# Altium schematic sheet IO+ROM board.SchDoc("IO+ROM board")
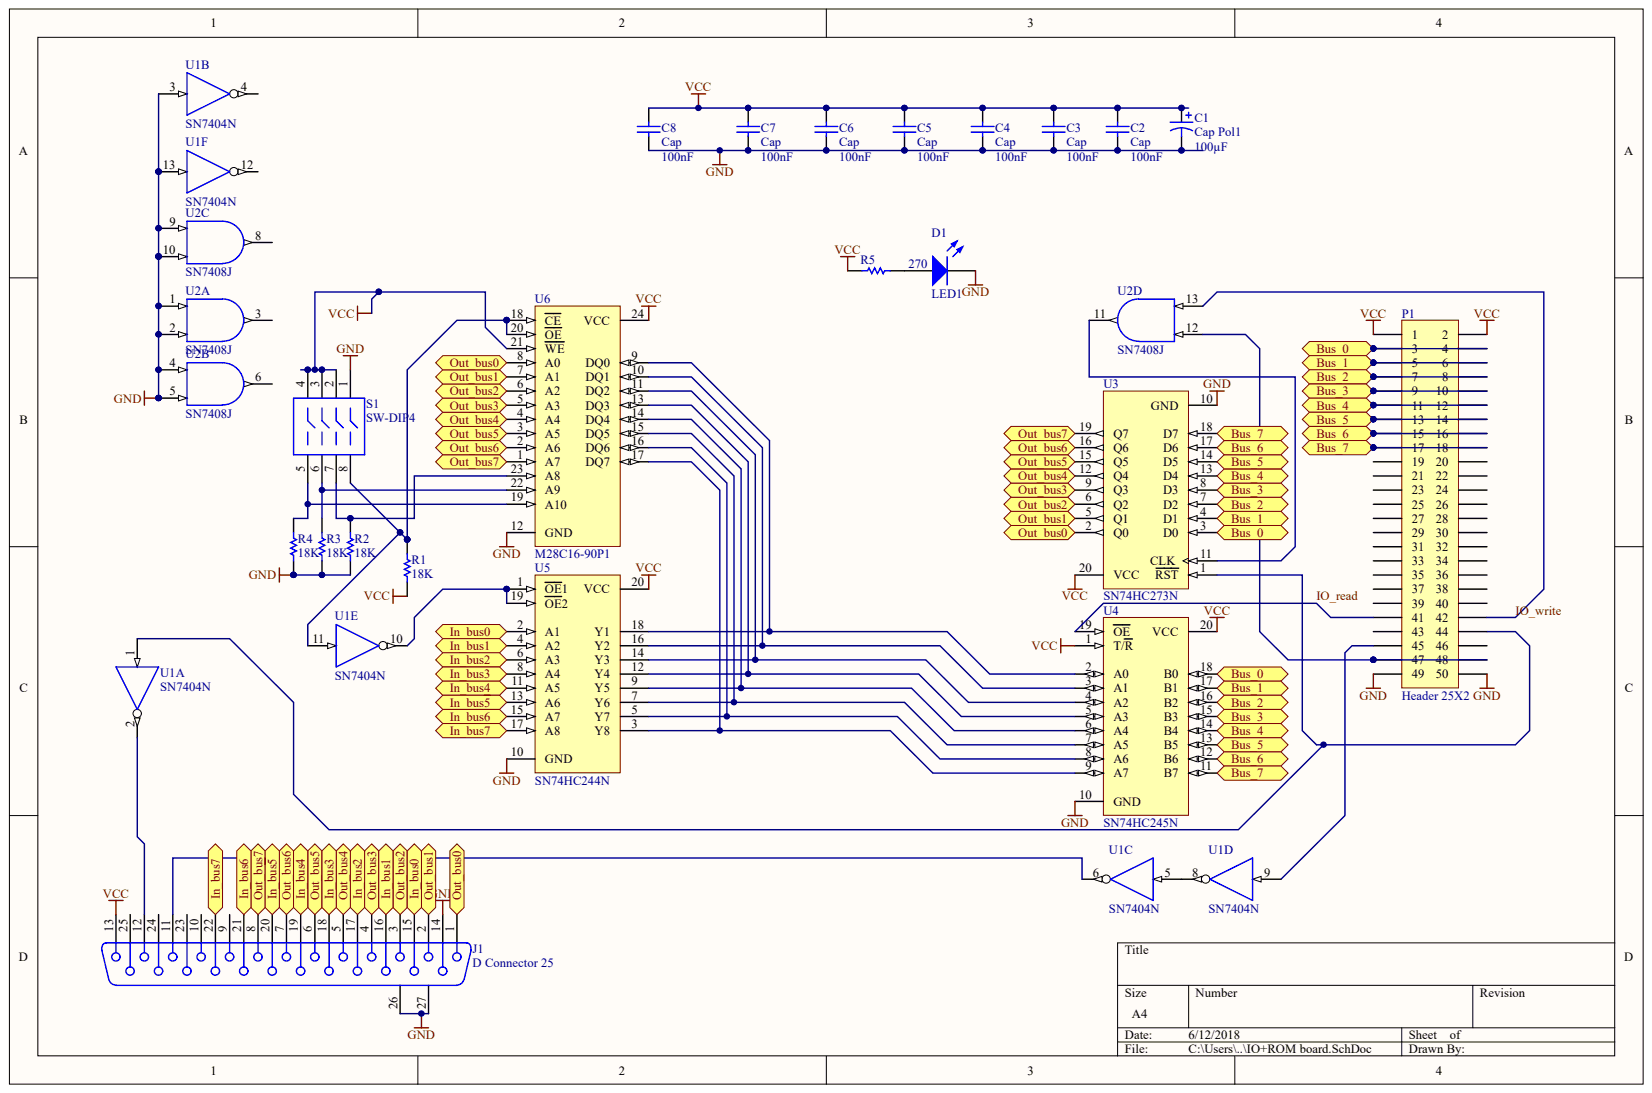
[diagram p0: IO+ROM board.SchDoc]

## Parts
C1  Cap Pol1  value 100µF
C2  Cap  value 100nF
C3  Cap  value 100nF
C4  Cap  value 100nF
C5  Cap  value 100nF
C6  Cap  value 100nF
C7  Cap  value 100nF
C8  Cap  value 100nF
D1  LED1
J1  D Connector 25
P1  Header 25X2
R1  value 18K  (hidden comment: Res1)
R2  value 18K  (hidden comment: Res1)
R3  value 18K  (hidden comment: Res1)
R4  value 18K  (hidden comment: Res1)
R5  value 270  (hidden comment: Res1)
S1  SW-DIP4
U1  SN7404N  (parts A,B,C,D,E,F)
U2  SN7408J  (parts A,B,C,D)
U3  SN74HC273N
U4  SN74HC245N
U5  SN74HC244N
U6  M28C16-90P1

## Ports
BUS_0, BUS_1, BUS_2, BUS_3, BUS_4, BUS_5, BUS_6, BUS_7, IN_BUS0, IN_BUS1, IN_BUS2, IN_BUS3, IN_BUS4, IN_BUS6, IN_BUS7, IN_BUS~{5}, OUT_BUS0, OUT_BUS1, OUT_BUS2, OUT_BUS3, OUT_BUS4, OUT_BUS5, OUT_BUS6, OUT_BUS7

## Nets
GND: C1.2 C2.1 C3.1 C4.1 C5.1 C6.1 C7.1 C8.1 D1.2 J1.14 J1.26 J1.27 P1.49 P1.50 R2.2 R3.2 R4.2 S1.1 U1.3 U1.7 U1.13 U2.1 U2.2 U2.4 U2.5 U2.7 U2.9 U2.10 U3.10(GND) U4.10(GND) U5.10(GND) U6.12(GND)
VCC: C1.1 C2.2 C3.2 C4.2 C5.2 C6.2 C7.2 C8.2 J1.13 P1.1 P1.2 R1.1 R5.1 S1.2 S1.3 S1.4 U1.14 U2.14 U3.20(VCC) U4.1(T/R) U4.20(VCC) U5.20(VCC) U6.21(WE) U6.24(VCC)
IO_READ: P1.41 U4.19(OE)
IO_WRITE: P1.42 U2.13
NetD1_1: D1.1 R5.2
NetJ1_1: J1.1 U3.2(Q0) U6.8(A0) ; port OUT_BUS0
NetJ1_2: J1.2 U3.5(Q1) U6.7(A1) ; port OUT_BUS1
NetJ1_3: J1.3 U3.6(Q2) U6.6(A2) ; port OUT_BUS2
NetJ1_4: J1.4 U3.9(Q3) U6.5(A3) ; port OUT_BUS3
NetJ1_5: J1.5 U3.12(Q4) U6.4(A4) ; port OUT_BUS4
NetJ1_6: J1.6 U3.15(Q5) U6.3(A5) ; port OUT_BUS5
NetJ1_7: J1.7 U3.16(Q6) U6.2(A6) ; port OUT_BUS6
NetJ1_8: J1.8 U3.19(Q7) U6.1(A7) ; port OUT_BUS7
NetJ1_11: J1.11 U1.6
NetJ1_12: J1.12 U1.2
NetJ1_15: J1.15 U5.2(A1) ; port IN_BUS0
NetJ1_16: J1.16 U5.4(A2) ; port IN_BUS1
NetJ1_17: J1.17 U5.6(A3) ; port IN_BUS2
NetJ1_18: J1.18 U5.8(A4) ; port IN_BUS3
NetJ1_19: J1.19 U5.11(A5) ; port IN_BUS4
NetJ1_20: J1.20 U5.13(A6) ; port IN_BUS~{5}
NetJ1_21: J1.21 U5.15(A7) ; port IN_BUS6
NetJ1_22: J1.22 U5.17(A8) ; port IN_BUS7
NetP1_3: P1.3 P1.4 U3.3(D0) U4.18(B0) ; port BUS_0
NetP1_5: P1.5 P1.6 U3.4(D1) U4.17(B1) ; port BUS_1
NetP1_7: P1.7 P1.8 U3.7(D2) U4.16(B2) ; port BUS_2
NetP1_9: P1.9 P1.10 U3.8(D3) U4.15(B3) ; port BUS_3
NetP1_11: P1.11 P1.12 U3.13(D4) U4.14(B4) ; port BUS_4
NetP1_13: P1.13 P1.14 U3.14(D5) U4.13(B5) ; port BUS_5
NetP1_15: P1.15 P1.16 U3.17(D6) U4.12(B6) ; port BUS_6
NetP1_17: P1.17 P1.18 U3.18(D7) U4.11(B7) ; port BUS_7
NetP1_44: P1.44 U1.1 U3.1(RST)
NetP1_45: P1.45 U1.9
NetP1_47: P1.47 P1.48 U2.12
NetR1_2: R1.2 S1.8 U1.11 U6.18(CE) U6.20(OE)
NetR2_1: R2.1 S1.7 U6.23(A8)
NetR3_1: R3.1 S1.6 U6.22(A9)
NetR4_1: R4.1 S1.5 U6.19(A10)
NetU1_5: U1.5 U1.8
NetU1_10: U1.10 U5.1(OE1) U5.19(OE2)
NetU2_11: U2.11 U3.11(CLK)
NetU4_2: U4.2(A0) U5.18(Y1) U6.9(DQ0)
NetU4_3: U4.3(A1) U5.16(Y2) U6.10(DQ1)
NetU4_4: U4.4(A2) U5.14(Y3) U6.11(DQ2)
NetU4_5: U4.5(A3) U5.12(Y4) U6.13(DQ3)
NetU4_6: U4.6(A4) U5.9(Y5) U6.14(DQ4)
NetU4_7: U4.7(A5) U5.7(Y6) U6.15(DQ5)
NetU4_8: U4.8(A6) U5.5(Y7) U6.16(DQ6)
NetU4_9: U4.9(A7) U5.3(Y8) U6.17(DQ7)

Not connected: J1 9 10 23 24 25; P1 19 20 21 22 23 24 25 26 27 28 29 30 31 32 33 34 35 36 37 38 39 40 43 46; U1 4 12; U2 3 6 8

## Library data of the parts
J1 = (no symbol name): PackageDocument: U; Positions: 25; Gender: Receptacle; Published: 15-Jun-2001; LatestRevisionDate: 17-Jul-2002; LatestRevisionNote: Re-released for DXP Platform.; DatasheetDocument: 19-Mar-1999; PackageReference: 787202; Orientation: Right Angle; Publisher: Altium Limited
C1-C8 D1 P1 R1-R5 S1 U1-U6 = (no symbol name): Publisher: Altium Limited
  C1: Published: 8-Jun-2000; LatestRevisionDate: 17-Jul-2002; LatestRevisionNote: Re-released for DXP Platform.; PackageReference: RB7.6-15; PackageDescription: Polarized Capacitor (Radial); 2 Leads
  C2-C8: Published: 8-Jun-2000; LatestRevisionDate: 17-Jul-2002; LatestRevisionNote: Re-released for DXP Platform.; PackageReference: RAD-0.3; PackageDescription: Radial Cap, Thru-Hole; 2 Leads; 0.3 in Pin Spacing
  D1: Published: 8-Jun-2000; LatestRevisionDate: 08-Jul-2005; LatestRevisionNote: Mech Layer 1 removed.; PackageReference: LED-1; PackageDescription: LED; 2 Leads
  P1: LatestRevisionDate: 17-Jul-2002; LatestRevisionNote: Re-released for DXP Platform.
  R1-R5: Published: 8-Jun-2000; LatestRevisionDate: 17-Jul-2002; LatestRevisionNote: Re-released for DXP Platform.; PackageReference: AXIAL-0.3; PackageDescription: Axial Device, Thru-Hole; 2 Leads; 0.3 in Pin Spacing
  S1: Published: 24-Mar-1999; LatestRevisionDate: 17-Jul-2002; LatestRevisionNote: Re-released for DXP Platform.; PackageReference: DIP-8
  U1: Published: 8-Jun-2000; LatestRevisionDate: 04-Mar-2005; LatestRevisionNote: Stylized 3D Model Added.; DatasheetVersion: Mar-1988; PackageVersion: Oct-1995; PackageReference: N014; PackageDescription: DIP; 14 Leads; Row Spacing 7.62 mm; Pitch 2.54 mm; ComponentLink1Description: Manufacturer Link; ComponentLink1URL: http://www.ti.com/; ComponentLink2Description: Datasheet; ComponentLink2URL: http://www-s.ti.com/sc/ds/sn5404.pdf; ComponentLink3Description: Packaging Specification; ComponentLink3URL: http://focus.ti.com/lit/ml/mpdi002c/mpdi002c.pdf
  U2: Published: 8-Jun-2000; LatestRevisionDate: 04-Mar-2005; LatestRevisionNote: Stylized 3D Model Added.; DatasheetVersion: Mar-1988; PackageVersion: Jun-1999; PackageReference: J014; PackageDescription: DIP; 14 Leads; Row Spacing 7.62 mm; Pitch 2.54 mm; ComponentLink1Description: Manufacturer Link; ComponentLink1URL: http://www.ti.com/; ComponentLink2Description: Datasheet; ComponentLink2URL: http://www-s.ti.com/sc/ds/sn54ls08.pdf; ComponentLink3Description: Packaging Specification; ComponentLink3URL: http://focus.ti.com/lit/ml/mcer002d/mcer002d.pdf
  U3: Published: 8-Jun-2000; LatestRevisionDate: 04-Mar-2005; LatestRevisionNote: Stylized 3D Model Added.; DatasheetVersion: May-1997; PackageVersion: Oct-1995; PackageReference: N020; PackageDescription: DIP; 20 Leads; Row Spacing 7.62 mm; Pitch 2.54 mm; ComponentLink1Description: Manufacturer Link; ComponentLink1URL: http://www.ti.com/; ComponentLink2Description: Datasheet; ComponentLink2URL: http://www-s.ti.com/sc/ds/sn74hc273.pdf
  U4: Published: 8-Jun-2000; LatestRevisionDate: 04-Mar-2005; LatestRevisionNote: Stylized 3D Model Added.; DatasheetVersion: May-1997; PackageVersion: Oct-1995; PackageReference: N020; PackageDescription: DIP; 20 Leads; Row Spacing 7.62 mm; Pitch 2.54 mm; ComponentLink1Description: Manufacturer Link; ComponentLink1URL: http://www.ti.com/; ComponentLink2Description: Datasheet; ComponentLink2URL: http://www-s.ti.com/sc/ds/sn74hc245.pdf
  U5: Published: 8-Jun-2000; LatestRevisionDate: 06-Jun-2005; LatestRevisionNote: Stylized 3D Model Added.; DatasheetVersion: May-1997; PackageVersion: Oct-1995; PackageReference: N020; PackageDescription: DIP; 20 Leads; Row Spacing 7.62 mm; Pitch 2.54 mm; ComponentLink1Description: Manufacturer Link; ComponentLink1URL: http://www.ti.com/; ComponentLink2Description: Datasheet; ComponentLink2URL: http://www-s.ti.com/sc/ds/sn74hc244.pdf
  U6: Published: 24-Aug-2001; LatestRevisionDate: 06-Jun-2005; LatestRevisionNote: Stylized 3D Model Added.; DatasheetVersion: Nov-1997; PackageReference: PDIP24; PackageDescription: DIP; 24 Leads; Row Spacing 15.24 mm; Pitch 2.54 mm; ComponentLink1Description: Manufacturer Link; ComponentLink1URL: http://www.st.com/
